# Altium schematic sheet LED_Monitor.SchDoc
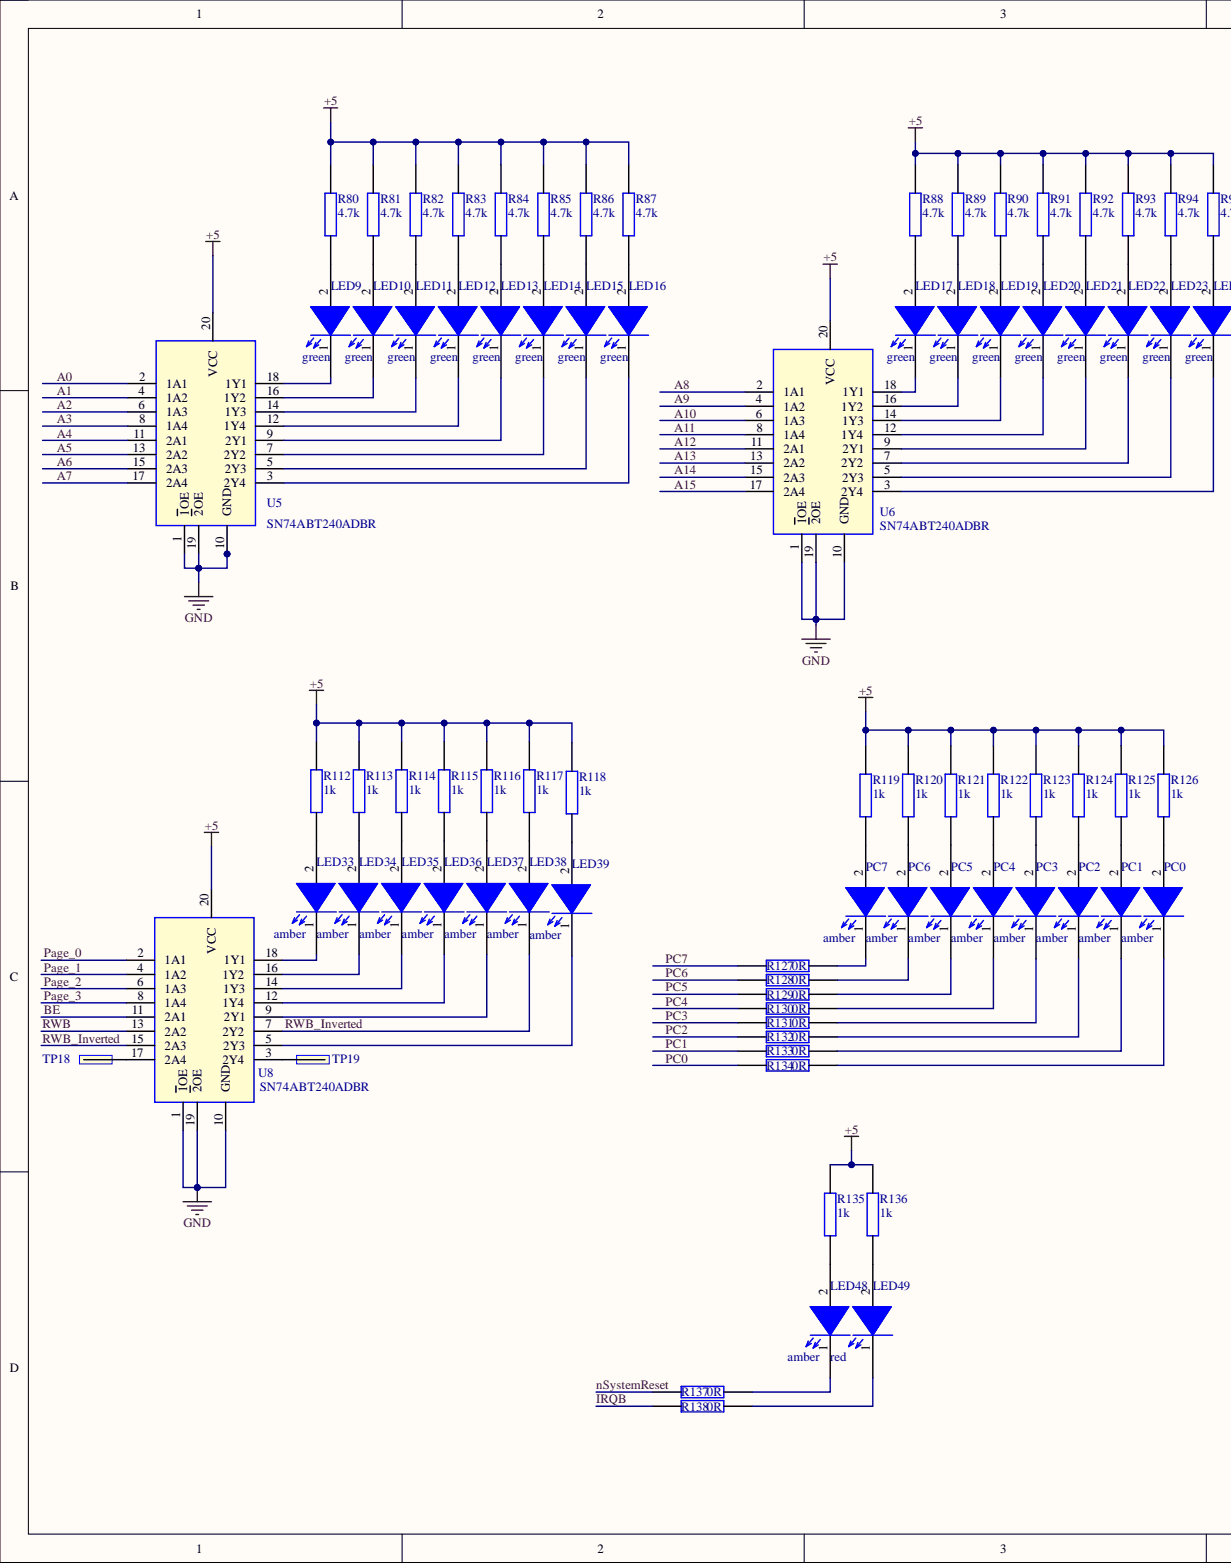
[diagram p0: LED_Monitor.SchDoc, left]
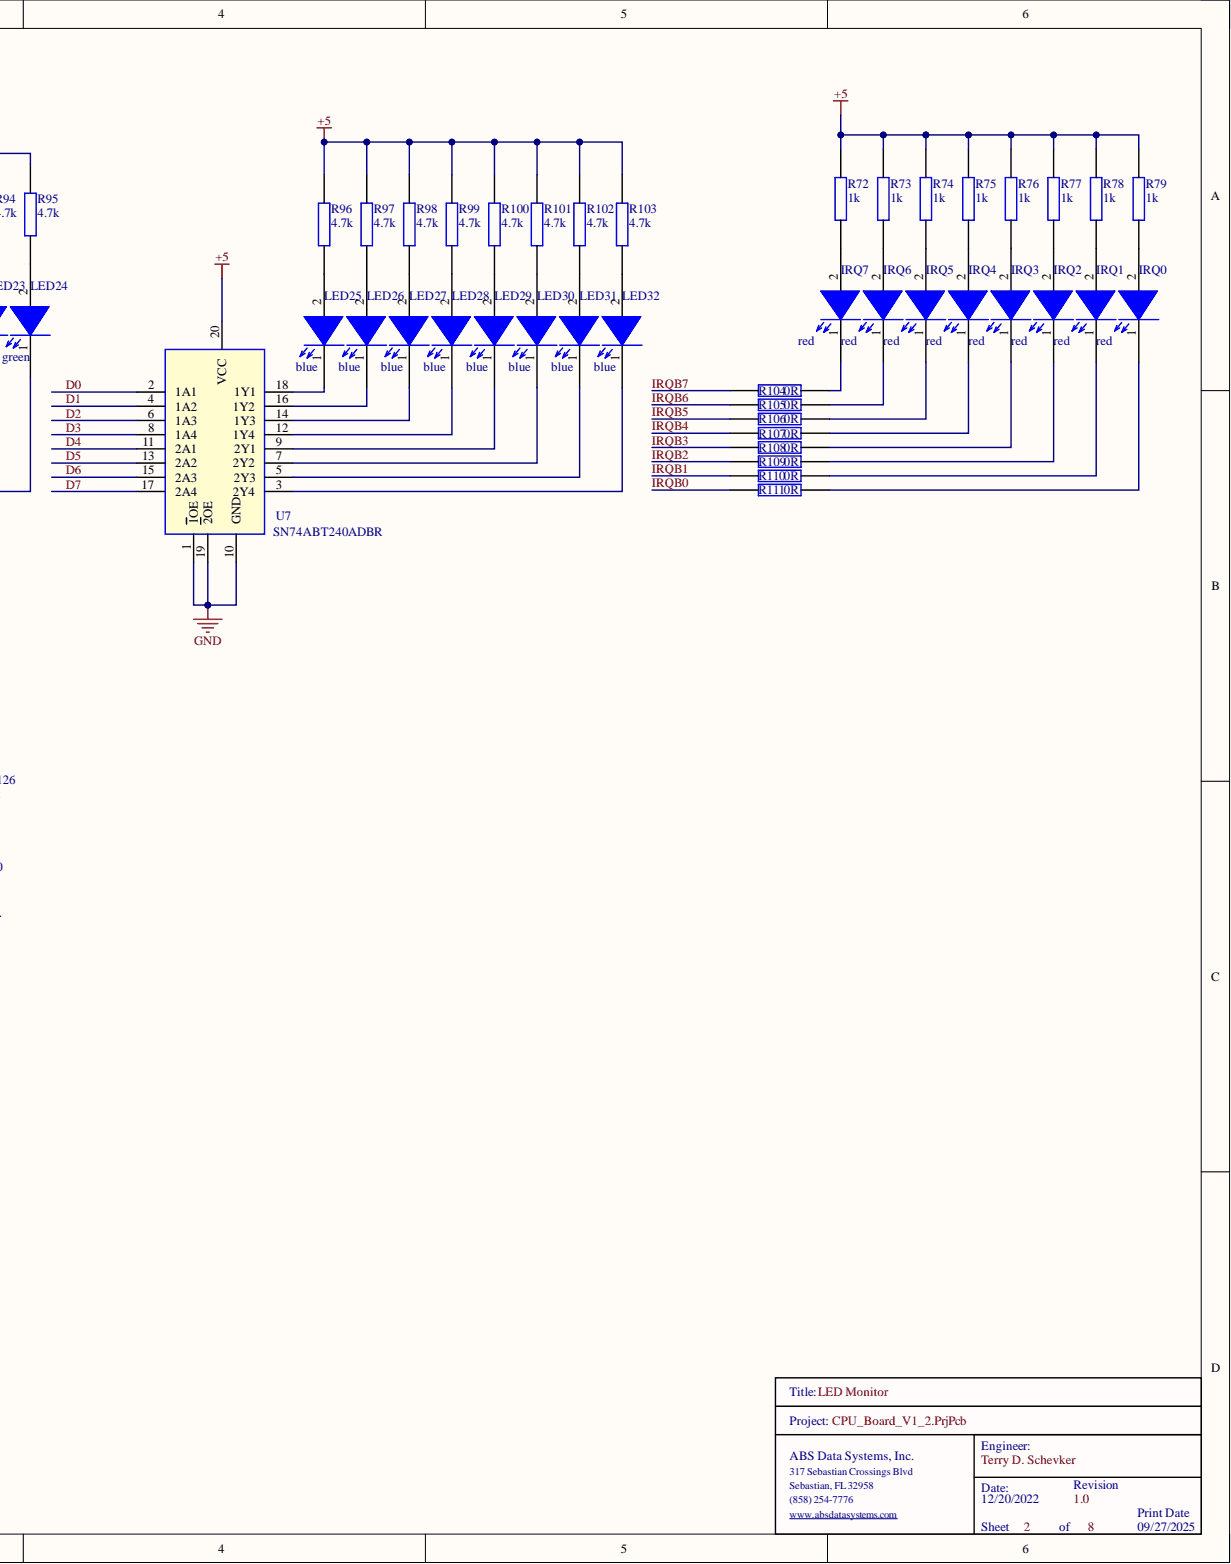
[diagram p1: LED_Monitor.SchDoc, right]

## Parts
IRQ0  red  [0603_Diode]
IRQ1  red  [0603_Diode]
IRQ2  red  [0603_Diode]
IRQ3  red  [0603_Diode]
IRQ4  red  [0603_Diode]
IRQ5  red  [0603_Diode]
IRQ6  red  [0603_Diode]
IRQ7  red  [0603_Diode]
LED9  green  [0603_Diode]
LED10  green  [0603_Diode]
LED11  green  [0603_Diode]
LED12  green  [0603_Diode]
LED13  green  [0603_Diode]
LED14  green  [0603_Diode]
LED15  green  [0603_Diode]
LED16  green  [0603_Diode]
LED17  green  [0603_Diode]
LED18  green  [0603_Diode]
LED19  green  [0603_Diode]
LED20  green  [0603_Diode]
LED21  green  [0603_Diode]
LED22  green  [0603_Diode]
LED23  green  [0603_Diode]
LED24  green  [0603_Diode]
LED25  blue  [0603_Diode]
LED26  blue  [0603_Diode]
LED27  blue  [0603_Diode]
LED28  blue  [0603_Diode]
LED29  blue  [0603_Diode]
LED30  blue  [0603_Diode]
LED31  blue  [0603_Diode]
LED32  blue  [0603_Diode]
LED33  amber  [0603_Diode]
LED34  amber  [0603_Diode]
LED35  amber  [0603_Diode]
LED36  amber  [0603_Diode]
LED37  amber  [0603_Diode]
LED38  amber  [0603_Diode]
LED39  amber  [0603_Diode]
LED48  amber  [0603_Diode]
LED49  red  [0603_Diode]
PC0  amber  [0603_Diode]
PC1  amber  [0603_Diode]
PC2  amber  [0603_Diode]
PC3  amber  [0603_Diode]
PC4  amber  [0603_Diode]
PC5  amber  [0603_Diode]
PC6  amber  [0603_Diode]
PC7  amber  [0603_Diode]
R72  1k  [0603]
R73  1k  [0603]
R74  1k  [0603]
R75  1k  [0603]
R76  1k  [0603]
R77  1k  [0603]
R78  1k  [0603]
R79  1k  [0603]
R80  4.7k  [0603]
R81  4.7k  [0603]
R82  4.7k  [0603]
R83  4.7k  [0603]
R84  4.7k  [0603]
R85  4.7k  [0603]
R86  4.7k  [0603]
R87  4.7k  [0603]
R88  4.7k  [0603]
R89  4.7k  [0603]
R90  4.7k  [0603]
R91  4.7k  [0603]
R92  4.7k  [0603]
R93  4.7k  [0603]
R94  4.7k  [0603]
R95  4.7k  [0603]
R96  4.7k  [0603]
R97  4.7k  [0603]
R98  4.7k  [0603]
R99  4.7k  [0603]
R100  4.7k  [0603]
R101  4.7k  [0603]
R102  4.7k  [0603]
R103  4.7k  [0603]
R104  0R  [0603]  (value not drawn)
R105  0R  [0603]  (value not drawn)
R106  0R  [0603]  (value not drawn)
R107  0R  [0603]  (value not drawn)
R108  0R  [0603]  (value not drawn)
R109  0R  [0603]  (value not drawn)
R110  0R  [0603]  (value not drawn)
R111  0R  [0603]  (value not drawn)
R112  1k  [0603]
R113  1k  [0603]
R114  1k  [0603]
R115  1k  [0603]
R116  1k  [0603]
R117  1k  [0603]
R118  1k  [0603]
R119  1k  [0603]
R120  1k  [0603]
R121  1k  [0603]
R122  1k  [0603]
R123  1k  [0603]
R124  1k  [0603]
R125  1k  [0603]
R126  1k  [0603]
R127  0R  [0603]  (value not drawn)
R128  0R  [0603]  (value not drawn)
R129  0R  [0603]  (value not drawn)
R130  0R  [0603]  (value not drawn)
R131  0R  [0603]  (value not drawn)
R132  0R  [0603]  (value not drawn)
R133  0R  [0603]  (value not drawn)
R134  0R  [0603]  (value not drawn)
R135  1k  [0603]
R136  1k  [0603]
R137  0R  [0603]  (value not drawn)
R138  0R  [0603]  (value not drawn)
TP18  TP  [TP]
TP19  TP  [TP]
U5  SN74ABT240ADBR  [SOP65P780X200-20N]
U6  SN74ABT240ADBR  [SOP65P780X200-20N]
U7  SN74ABT240ADBR  [SOP65P780X200-20N]
U8  SN74ABT240ADBR  [SOP65P780X200-20N]

## Nets
+5: R72.1 R73.1 R74.1 R75.1 R76.1 R77.1 R78.1 R79.1 R80.2 R81.2 R82.2 R83.2 R84.2 R85.2 R86.2 R87.2 R88.2 R89.2 R90.2 R91.2 R92.2 R93.2 R94.2 R95.2 R96.1 R97.1 R98.1 R99.1 R100.1 R101.1 R102.1 R103.1 R112.1 R113.1 R114.1 R115.1 R116.1 R117.1 R118.1 R119.1 R120.1 R121.1 R122.1 R123.1 R124.1 R125.1 R126.1 R135.1 R136.1 U5.20(VCC) U6.20(VCC) U7.20(VCC) U8.20(VCC)
GND: U5.1(1OE) U5.10(GND) U5.19(2OE) U6.1(1OE) U6.10(GND) U6.19(2OE) U7.1(1OE) U7.10(GND) U7.19(2OE) U8.1(1OE) U8.10(GND) U8.19(2OE)
A0: U5.2(1A1)
A1: U5.4(1A2)
A2: U5.6(1A3)
A3: U5.8(1A4)
A4: U5.11(2A1)
A5: U5.13(2A2)
A6: U5.15(2A3)
A7: U5.17(2A4)
A8: U6.2(1A1)
A9: U6.4(1A2)
A10: U6.6(1A3)
A11: U6.8(1A4)
A12: U6.11(2A1)
A13: U6.13(2A2)
A14: U6.15(2A3)
A15: U6.17(2A4)
BE: U8.11(2A1)
D0: U7.2(1A1)
D1: U7.4(1A2)
D2: U7.6(1A3)
D3: U7.8(1A4)
D4: U7.11(2A1)
D5: U7.13(2A2)
D6: U7.15(2A3)
D7: U7.17(2A4)
IRQB: R138.1
IRQB0: R111.1
IRQB1: R110.1
IRQB2: R109.1
IRQB3: R108.1
IRQB4: R107.1
IRQB5: R106.1
IRQB6: R105.1
IRQB7: R104.1
nSystemReset: R137.1
Page_0: U8.2(1A1)
Page_1: U8.4(1A2)
Page_2: U8.6(1A3)
Page_3: U8.8(1A4)
PC0: R134.1
PC1: R133.1
PC2: R132.1
PC3: R131.1
PC4: R130.1
PC5: R129.1
PC6: R128.1
PC7: R127.1
RWB: U8.13(2A2)
RWB_Inverted: LED38.1 U8.7(2Y2) U8.15(2A3)
NetIRQ0_1: IRQ0.1 R111.2
NetIRQ0_2: IRQ0.2 R79.2
NetIRQ1_1: IRQ1.1 R110.2
NetIRQ1_2: IRQ1.2 R78.2
NetIRQ2_1: IRQ2.1 R109.2
NetIRQ2_2: IRQ2.2 R77.2
NetIRQ3_1: IRQ3.1 R108.2
NetIRQ3_2: IRQ3.2 R76.2
NetIRQ4_1: IRQ4.1 R107.2
NetIRQ4_2: IRQ4.2 R75.2
NetIRQ5_1: IRQ5.1 R106.2
NetIRQ5_2: IRQ5.2 R74.2
NetIRQ6_1: IRQ6.1 R105.2
NetIRQ6_2: IRQ6.2 R73.2
NetIRQ7_1: IRQ7.1 R104.2
NetIRQ7_2: IRQ7.2 R72.2
NetLED9_1: LED9.1 U5.18(1Y1)
NetLED9_2: LED9.2 R80.1
NetLED10_1: LED10.1 U5.16(1Y2)
NetLED10_2: LED10.2 R81.1
NetLED11_1: LED11.1 U5.14(1Y3)
NetLED11_2: LED11.2 R82.1
NetLED12_1: LED12.1 U5.12(1Y4)
NetLED12_2: LED12.2 R83.1
NetLED13_1: LED13.1 U5.9(2Y1)
NetLED13_2: LED13.2 R84.1
NetLED14_1: LED14.1 U5.7(2Y2)
NetLED14_2: LED14.2 R85.1
NetLED15_1: LED15.1 U5.5(2Y3)
NetLED15_2: LED15.2 R86.1
NetLED16_1: LED16.1 U5.3(2Y4)
NetLED16_2: LED16.2 R87.1
NetLED17_1: LED17.1 U6.18(1Y1)
NetLED17_2: LED17.2 R88.1
NetLED18_1: LED18.1 U6.16(1Y2)
NetLED18_2: LED18.2 R89.1
NetLED19_1: LED19.1 U6.14(1Y3)
NetLED19_2: LED19.2 R90.1
NetLED20_1: LED20.1 U6.12(1Y4)
NetLED20_2: LED20.2 R91.1
NetLED21_1: LED21.1 U6.9(2Y1)
NetLED21_2: LED21.2 R92.1
NetLED22_1: LED22.1 U6.7(2Y2)
NetLED22_2: LED22.2 R93.1
NetLED23_1: LED23.1 U6.5(2Y3)
NetLED23_2: LED23.2 R94.1
NetLED24_1: LED24.1 U6.3(2Y4)
NetLED24_2: LED24.2 R95.1
NetLED25_1: LED25.1 U7.18(1Y1)
NetLED25_2: LED25.2 R96.2
NetLED26_1: LED26.1 U7.16(1Y2)
NetLED26_2: LED26.2 R97.2
NetLED27_1: LED27.1 U7.14(1Y3)
NetLED27_2: LED27.2 R98.2
NetLED28_1: LED28.1 U7.12(1Y4)
NetLED28_2: LED28.2 R99.2
NetLED29_1: LED29.1 U7.9(2Y1)
NetLED29_2: LED29.2 R100.2
NetLED30_1: LED30.1 U7.7(2Y2)
NetLED30_2: LED30.2 R101.2
NetLED31_1: LED31.1 U7.5(2Y3)
NetLED31_2: LED31.2 R102.2
NetLED32_1: LED32.1 U7.3(2Y4)
NetLED32_2: LED32.2 R103.2
NetLED33_1: LED33.1 U8.18(1Y1)
NetLED33_2: LED33.2 R112.2
NetLED34_1: LED34.1 U8.16(1Y2)
NetLED34_2: LED34.2 R113.2
NetLED35_1: LED35.1 U8.14(1Y3)
NetLED35_2: LED35.2 R114.2
NetLED36_1: LED36.1 U8.12(1Y4)
NetLED36_2: LED36.2 R115.2
NetLED37_1: LED37.1 U8.9(2Y1)
NetLED37_2: LED37.2 R116.2
NetLED38_2: LED38.2 R117.2
NetLED39_1: LED39.1 U8.5(2Y3)
NetLED39_2: LED39.2 R118.2
NetLED48_1: LED48.1 R137.2
NetLED48_2: LED48.2 R135.2
NetLED49_1: LED49.1 R138.2
NetLED49_2: LED49.2 R136.2
NetPC0_1: PC0.1 R134.2
NetPC0_2: PC0.2 R126.2
NetPC1_1: PC1.1 R133.2
NetPC1_2: PC1.2 R125.2
NetPC2_1: PC2.1 R132.2
NetPC2_2: PC2.2 R124.2
NetPC3_1: PC3.1 R131.2
NetPC3_2: PC3.2 R123.2
NetPC4_1: PC4.1 R130.2
NetPC4_2: PC4.2 R122.2
NetPC5_1: PC5.1 R129.2
NetPC5_2: PC5.2 R121.2
NetPC6_1: PC6.1 R128.2
NetPC6_2: PC6.2 R120.2
NetPC7_1: PC7.1 R127.2
NetPC7_2: PC7.2 R119.2
NetTP18_1: TP18.1 U8.17(2A4)
NetTP19_1: TP19.1 U8.3(2Y4)

## Library data of the parts
IRQ0-IRQ7 = 150060RS75000: Wurth Elektronik 0603 red; Manufacturer: Wurth Electronics; Manufacturer Part Number: 150060GS75000; Dominant Wavelength: 520nm; Forward Current: 30mA; Forward Voltage: 3.2V; Lens Style: Clear,Rectangular; Luminous Intensity: 430mcd; Test Current: 20mA; Viewing Angle: 140°; Case Code (Imperial): 0603; Case Code (Metric): 1608; Case/Package: 0603; Category: LEDs; Color: Green; Component Kind: Standard; ComponentLink1Description: https://datasheet.ciiva.com/29562/150060gs75000-29562868.pdf?src-supplier=Digi-Key; ComponentLink1URL: https://datasheet.ciiva.com/29562/150060gs75000-29562868.pdf?src-supplier=Digi-Key; ComponentLink2Description: https://datasheet.ciiva.com/29279/759427-da-01-en-chipled-0603-w-kl-gruen-150060gs75000-29279201.pdf?src-supplier=Conrad; ComponentLink2URL: https://datasheet.ciiva.com/29279/759427-da-01-en-chipled-0603-w-kl-gruen-150060gs75000-29279201.pdf?src-supplier=Conrad; ComponentLink3Description: https://datasheet.ciiva.com/29562/150060gs75000-29562869.pdf?src-supplier=Digi-Key; ComponentLink3URL: https://datasheet.ciiva.com/29562/150060gs75000-29562869.pdf?src-supplier=Digi-Key; ComponentLink4Description: https://datasheet.ciiva.com/29562/150060gs75000-29562870.pdf?src-supplier=Digi-Key; ComponentLink4URL: https://datasheet.ciiva.com/29562/150060gs75000-29562870.pdf?src-supplier=Digi-Key; Height: 0.7mm; Lead Free: Lead Free; Length: 1.6mm; Library Name: Misc.SchLib; Max Operating Temperature: 85°C; Min Operating Temperature: -40°C; Mount: Surface Mount; Package Quantity: 4000; Packaging: Tape and Reel; Pricing 1: 1=0.14000, 50=0.13300, 100=0.11400, 500=0.09900 (USD); REACH SVHC: Unknown; RoHS: Yes; Stock 1: 71509; Supplier 1: Digi-Key; Wavelength: 520nm; Width: 0.8mm
LED9-LED24 = 150060GS75000: Wurth Elektronik 0603 green; Manufacturer: Wurth Electronics; Dominant Wavelength: 520nm; Forward Current: 30mA; Forward Voltage: 3.2V; Lens Style: Clear,Rectangular; Luminous Intensity: 430mcd; Test Current: 20mA; Viewing Angle: 140°; Case Code (Imperial): 0603; Case Code (Metric): 1608; Case/Package: 0603; Category: LEDs; Color: Green; Component Kind: Standard; ComponentLink1Description: https://datasheet.ciiva.com/29562/150060gs75000-29562868.pdf?src-supplier=Digi-Key; ComponentLink1URL: https://datasheet.ciiva.com/29562/150060gs75000-29562868.pdf?src-supplier=Digi-Key; ComponentLink2Description: https://datasheet.ciiva.com/29279/759427-da-01-en-chipled-0603-w-kl-gruen-150060gs75000-29279201.pdf?src-supplier=Conrad; ComponentLink2URL: https://datasheet.ciiva.com/29279/759427-da-01-en-chipled-0603-w-kl-gruen-150060gs75000-29279201.pdf?src-supplier=Conrad; ComponentLink3Description: https://datasheet.ciiva.com/29562/150060gs75000-29562869.pdf?src-supplier=Digi-Key; ComponentLink3URL: https://datasheet.ciiva.com/29562/150060gs75000-29562869.pdf?src-supplier=Digi-Key; ComponentLink4Description: https://datasheet.ciiva.com/29562/150060gs75000-29562870.pdf?src-supplier=Digi-Key; ComponentLink4URL: https://datasheet.ciiva.com/29562/150060gs75000-29562870.pdf?src-supplier=Digi-Key; Height: 0.7mm; Lead Free: Lead Free; Length: 1.6mm; Library Name: Misc.SchLib; Manufacturer Part Number: 150060GS75000; Max Operating Temperature: 85°C; Min Operating Temperature: -40°C; Mount: Surface Mount; Package Quantity: 4000; Packaging: Tape and Reel; Pricing 1: 1=0.14000, 50=0.13300, 100=0.11400, 500=0.09900 (USD); REACH SVHC: Unknown; RoHS: Yes; Stock 1: 71509; Supplier 1: Digi-Key; Wavelength: 520nm; Width: 0.8mm
LED25-LED32 = 150060BS75000: Wurth Elektronik 0603 blue; Manufacturer: Wurth Electronics; Manufacturer Part Number: 150060GS75000; Color: Green; Dominant Wavelength: 520nm; Forward Current: 30mA; Forward Voltage: 3.2V; Lens Style: Clear,Rectangular; Luminous Intensity: 430mcd; Test Current: 20mA; Viewing Angle: 140°; Case Code (Imperial): 0603; Case Code (Metric): 1608; Case/Package: 0603; Category: LEDs; Component Kind: Standard; ComponentLink1Description: https://datasheet.ciiva.com/29562/150060gs75000-29562868.pdf?src-supplier=Digi-Key; ComponentLink1URL: https://datasheet.ciiva.com/29562/150060gs75000-29562868.pdf?src-supplier=Digi-Key; ComponentLink2Description: https://datasheet.ciiva.com/29279/759427-da-01-en-chipled-0603-w-kl-gruen-150060gs75000-29279201.pdf?src-supplier=Conrad; ComponentLink2URL: https://datasheet.ciiva.com/29279/759427-da-01-en-chipled-0603-w-kl-gruen-150060gs75000-29279201.pdf?src-supplier=Conrad; ComponentLink3Description: https://datasheet.ciiva.com/29562/150060gs75000-29562869.pdf?src-supplier=Digi-Key; ComponentLink3URL: https://datasheet.ciiva.com/29562/150060gs75000-29562869.pdf?src-supplier=Digi-Key; ComponentLink4Description: https://datasheet.ciiva.com/29562/150060gs75000-29562870.pdf?src-supplier=Digi-Key; ComponentLink4URL: https://datasheet.ciiva.com/29562/150060gs75000-29562870.pdf?src-supplier=Digi-Key; Height: 0.7mm; Lead Free: Lead Free; Length: 1.6mm; Library Name: Misc.SchLib; Max Operating Temperature: 85°C; Min Operating Temperature: -40°C; Mount: Surface Mount; Package Quantity: 4000; Packaging: Tape and Reel; Pricing 1: 1=0.14000, 50=0.13300, 100=0.11400, 500=0.09900 (USD); REACH SVHC: Unknown; RoHS: Yes; Stock 1: 71509; Supplier 1: Digi-Key; Wavelength: 520nm; Width: 0.8mm
LED33-LED39 LED48 = 150060AS75000: Wurth Elektronik 0603 amber; Manufacturer: Wurth Electronics; Manufacturer Part Number: 150060GS75000; Color: Green; Dominant Wavelength: 520nm; Forward Current: 30mA; Forward Voltage: 3.2V; Lens Style: Clear,Rectangular; Luminous Intensity: 430mcd; Test Current: 20mA; Viewing Angle: 140°; Case Code (Imperial): 0603; Case Code (Metric): 1608; Case/Package: 0603; Category: LEDs; Component Kind: Standard; ComponentLink1Description: https://datasheet.ciiva.com/29562/150060gs75000-29562868.pdf?src-supplier=Digi-Key; ComponentLink1URL: https://datasheet.ciiva.com/29562/150060gs75000-29562868.pdf?src-supplier=Digi-Key; ComponentLink2Description: https://datasheet.ciiva.com/29279/759427-da-01-en-chipled-0603-w-kl-gruen-150060gs75000-29279201.pdf?src-supplier=Conrad; ComponentLink2URL: https://datasheet.ciiva.com/29279/759427-da-01-en-chipled-0603-w-kl-gruen-150060gs75000-29279201.pdf?src-supplier=Conrad; ComponentLink3Description: https://datasheet.ciiva.com/29562/150060gs75000-29562869.pdf?src-supplier=Digi-Key; ComponentLink3URL: https://datasheet.ciiva.com/29562/150060gs75000-29562869.pdf?src-supplier=Digi-Key; ComponentLink4Description: https://datasheet.ciiva.com/29562/150060gs75000-29562870.pdf?src-supplier=Digi-Key; ComponentLink4URL: https://datasheet.ciiva.com/29562/150060gs75000-29562870.pdf?src-supplier=Digi-Key; Height: 0.7mm; Lead Free: Lead Free; Length: 1.6mm; Library Name: Misc.SchLib; Max Operating Temperature: 85°C; Min Operating Temperature: -40°C; Mount: Surface Mount; Package Quantity: 4000; Packaging: Tape and Reel; Pricing 1: 1=0.14000, 50=0.13300, 100=0.11400, 500=0.09900 (USD); REACH SVHC: Unknown; RoHS: Yes; Stock 1: 71509; Supplier 1: Digi-Key; Wavelength: 520nm; Width: 0.8mm
LED49 = 150060RS75000: Wurth Elektronik 0603 red; Manufacturer: Wurth Electronics; Manufacturer Part Number: 150060GS75000; Color: Green; Dominant Wavelength: 520nm; Forward Current: 30mA; Forward Voltage: 3.2V; Lens Style: Clear,Rectangular; Luminous Intensity: 430mcd; Test Current: 20mA; Viewing Angle: 140°; Case Code (Imperial): 0603; Case Code (Metric): 1608; Case/Package: 0603; Category: LEDs; Component Kind: Standard; ComponentLink1Description: https://datasheet.ciiva.com/29562/150060gs75000-29562868.pdf?src-supplier=Digi-Key; ComponentLink1URL: https://datasheet.ciiva.com/29562/150060gs75000-29562868.pdf?src-supplier=Digi-Key; ComponentLink2Description: https://datasheet.ciiva.com/29279/759427-da-01-en-chipled-0603-w-kl-gruen-150060gs75000-29279201.pdf?src-supplier=Conrad; ComponentLink2URL: https://datasheet.ciiva.com/29279/759427-da-01-en-chipled-0603-w-kl-gruen-150060gs75000-29279201.pdf?src-supplier=Conrad; ComponentLink3Description: https://datasheet.ciiva.com/29562/150060gs75000-29562869.pdf?src-supplier=Digi-Key; ComponentLink3URL: https://datasheet.ciiva.com/29562/150060gs75000-29562869.pdf?src-supplier=Digi-Key; ComponentLink4Description: https://datasheet.ciiva.com/29562/150060gs75000-29562870.pdf?src-supplier=Digi-Key; ComponentLink4URL: https://datasheet.ciiva.com/29562/150060gs75000-29562870.pdf?src-supplier=Digi-Key; Height: 0.7mm; Lead Free: Lead Free; Length: 1.6mm; Library Name: Misc.SchLib; Max Operating Temperature: 85°C; Min Operating Temperature: -40°C; Mount: Surface Mount; Package Quantity: 4000; Packaging: Tape and Reel; Pricing 1: 1=0.14000, 50=0.13300, 100=0.11400, 500=0.09900 (USD); REACH SVHC: Unknown; RoHS: Yes; Stock 1: 71509; Supplier 1: Digi-Key; Wavelength: 520nm; Width: 0.8mm
PC0-PC7 = 150060AS75000: Wurth Elektronik 0603 amber; Manufacturer: Wurth Electronics; Manufacturer Part Number: 150060GS75000; Dominant Wavelength: 520nm; Forward Current: 30mA; Forward Voltage: 3.2V; Lens Style: Clear,Rectangular; Luminous Intensity: 430mcd; Test Current: 20mA; Viewing Angle: 140°; Case Code (Imperial): 0603; Case Code (Metric): 1608; Case/Package: 0603; Category: LEDs; Color: Green; Component Kind: Standard; ComponentLink1Description: https://datasheet.ciiva.com/29562/150060gs75000-29562868.pdf?src-supplier=Digi-Key; ComponentLink1URL: https://datasheet.ciiva.com/29562/150060gs75000-29562868.pdf?src-supplier=Digi-Key; ComponentLink2Description: https://datasheet.ciiva.com/29279/759427-da-01-en-chipled-0603-w-kl-gruen-150060gs75000-29279201.pdf?src-supplier=Conrad; ComponentLink2URL: https://datasheet.ciiva.com/29279/759427-da-01-en-chipled-0603-w-kl-gruen-150060gs75000-29279201.pdf?src-supplier=Conrad; ComponentLink3Description: https://datasheet.ciiva.com/29562/150060gs75000-29562869.pdf?src-supplier=Digi-Key; ComponentLink3URL: https://datasheet.ciiva.com/29562/150060gs75000-29562869.pdf?src-supplier=Digi-Key; ComponentLink4Description: https://datasheet.ciiva.com/29562/150060gs75000-29562870.pdf?src-supplier=Digi-Key; ComponentLink4URL: https://datasheet.ciiva.com/29562/150060gs75000-29562870.pdf?src-supplier=Digi-Key; Height: 0.7mm; Lead Free: Lead Free; Length: 1.6mm; Library Name: Misc.SchLib; Max Operating Temperature: 85°C; Min Operating Temperature: -40°C; Mount: Surface Mount; Package Quantity: 4000; Packaging: Tape and Reel; Pricing 1: 1=0.14000, 50=0.13300, 100=0.11400, 500=0.09900 (USD); REACH SVHC: Unknown; RoHS: Yes; Stock 1: 71509; Supplier 1: Digi-Key; Wavelength: 520nm; Width: 0.8mm
R72-R79 R112-R126 R135 R136 = AC0603FR-7W1KL_1: YAGEO 0603 1k ohm; Manufacturer_Name: KEMET; Manufacturer_Part_Number: RC0603JR-070RL; Geometry.Height: 0.55mm; Component Kind: Standard; Datasheet Link: https://datasheet.datasheetarchive.com/originals/distributors/Datasheets_SAMA/902f9e387b938f871d31120f5fc1d65e.pdf; Library Name: Resistors.SchLib; Mouser Part Number: 603-RC0603JR-070RL; Mouser Price/Stock: https://www.mouser.co.uk/ProductDetail/YAGEO/RC0603JR-070RL?qs=2cAdsCoAWRGWyPcuXgGiXQ%3D%3D
R80-R103 = RC0603FR-104K7L: YAGEO 0603 4.7k; Manufacturer_Name: KEMET; Manufacturer_Part_Number: RC0603JR-070RL; Geometry.Height: 0.55mm; Component Kind: Standard; Datasheet Link: https://datasheet.datasheetarchive.com/originals/distributors/Datasheets_SAMA/902f9e387b938f871d31120f5fc1d65e.pdf; Library Name: Resistors.SchLib; Mouser Part Number: 603-RC0603JR-070RL; Mouser Price/Stock: https://www.mouser.co.uk/ProductDetail/YAGEO/RC0603JR-070RL?qs=2cAdsCoAWRGWyPcuXgGiXQ%3D%3D
R104-R111 R127-R134 R137 R138 = RC0603JR-070RL: YAGEO 0603 0 ohm; Manufacturer_Name: KEMET; Geometry.Height: 0.55mm; Component Kind: Standard; Datasheet Link: https://datasheet.datasheetarchive.com/originals/distributors/Datasheets_SAMA/902f9e387b938f871d31120f5fc1d65e.pdf; Library Name: Resistors.SchLib; Manufacturer_Part_Number: RC0603JR-070RL; Mouser Part Number: 603-RC0603JR-070RL; Mouser Price/Stock: https://www.mouser.co.uk/ProductDetail/YAGEO/RC0603JR-070RL?qs=2cAdsCoAWRGWyPcuXgGiXQ%3D%3D
TP18 TP19 = TP: Manufacturer: Samtec; Manufacturer Part Number: TST-105-01-G-D; Connector Type: Male Pin; Gender: Male; Number of Contacts: 16; Pitch: 2.54mm; Row Spacing: 0.1inch; Category: Headers and Wire Housings; Color: Black; Component Kind: Standard; ComponentLink1Description: https://datasheet.ciiva.com/739/tst-mkt-739845.pdf?src-supplier=Digi-Key; ComponentLink1URL: https://datasheet.ciiva.com/739/tst-mkt-739845.pdf?src-supplier=Digi-Key; ComponentLink2Description: https://datasheet.ciiva.com/10018/htst-10018510.pdf?src-supplier=Avnet; ComponentLink2URL: https://datasheet.ciiva.com/10018/htst-10018510.pdf?src-supplier=Avnet; Contact Material: Bronze; Contact Mating Length: 0.25inch; Contact Plating: Gold; Depth: 9.27mm; Housing Color: Black; Housing Material: Polyester; Length: 27.94mm; Library Name: Misc.SchLib; Max Operating Temperature: 125°C; Min Operating Temperature: -55°C; Mount: Through Hole; Number of Positions: 16; Number of Rows: 2; Orientation: Straight; Packaging: Rail/Tube; Pricing 1: 1=2.85000, 10=2.73600, 100=2.28000, 500=1.93800, 1000=1.65300, 5000=1.48200 (USD); REACH SVHC: No SVHC; RoHS: Yes; Stock 1: 227; Supplier 1: Digi-Key; Termination: Solder
U5-U8 = SN74ABT240ADBR: Octal Buffers/Drivers With 3-State Outputs; Manufacturer_Name: Texas Instruments; Geometry.Height: 2mm; Component Kind: Standard; Datasheet Link: http://www.ti.com/lit/gpn/sn74abt240a; Library Name: Logic.SchLib; Manufacturer_Part_Number: SN74ABT240ADBR; Mouser Part Number: 595-SN74ABT240ADBR; Mouser Price/Stock: https://www.mouser.co.uk/ProductDetail/Texas-Instruments/SN74ABT240ADBR?qs=JHHQeKcAU3Dt82k%252BVyiYdw%3D%3D
